# Altium schematic sheet Sheet1.SchDoc
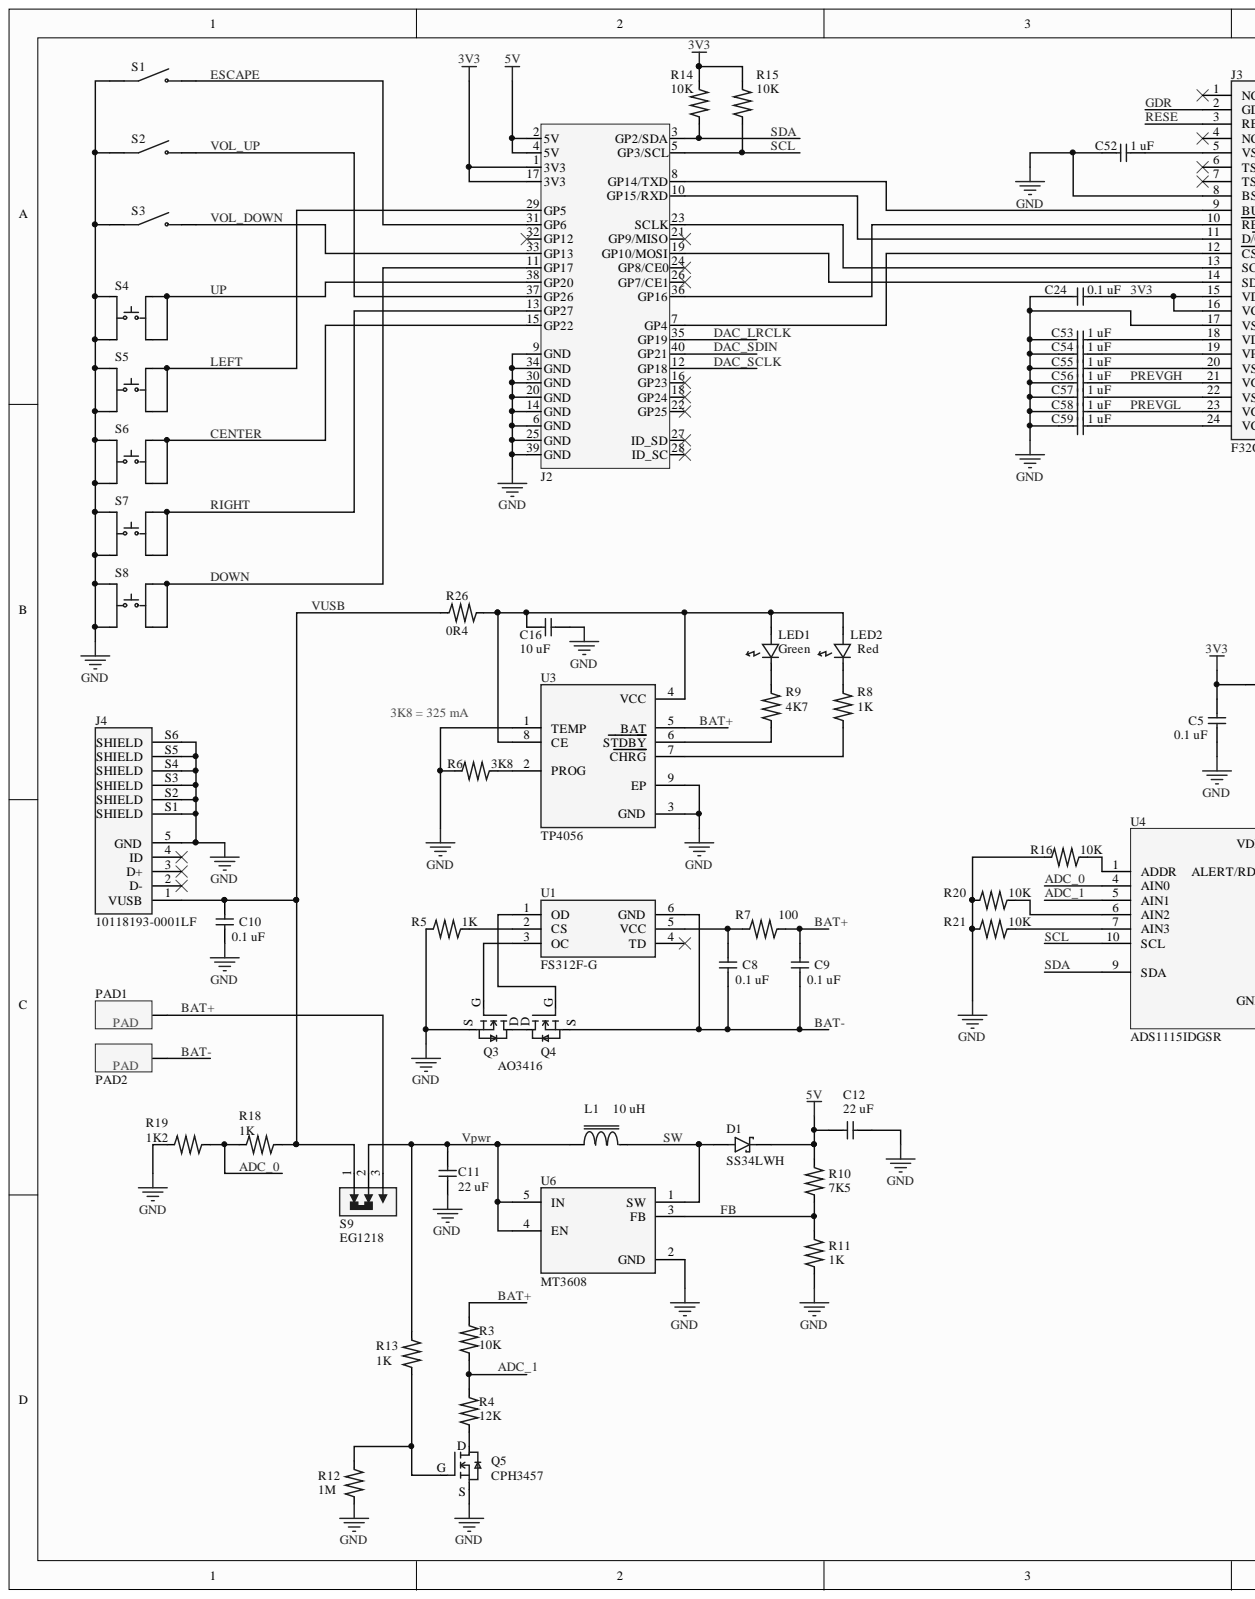
[diagram p0: Sheet1.SchDoc, left]
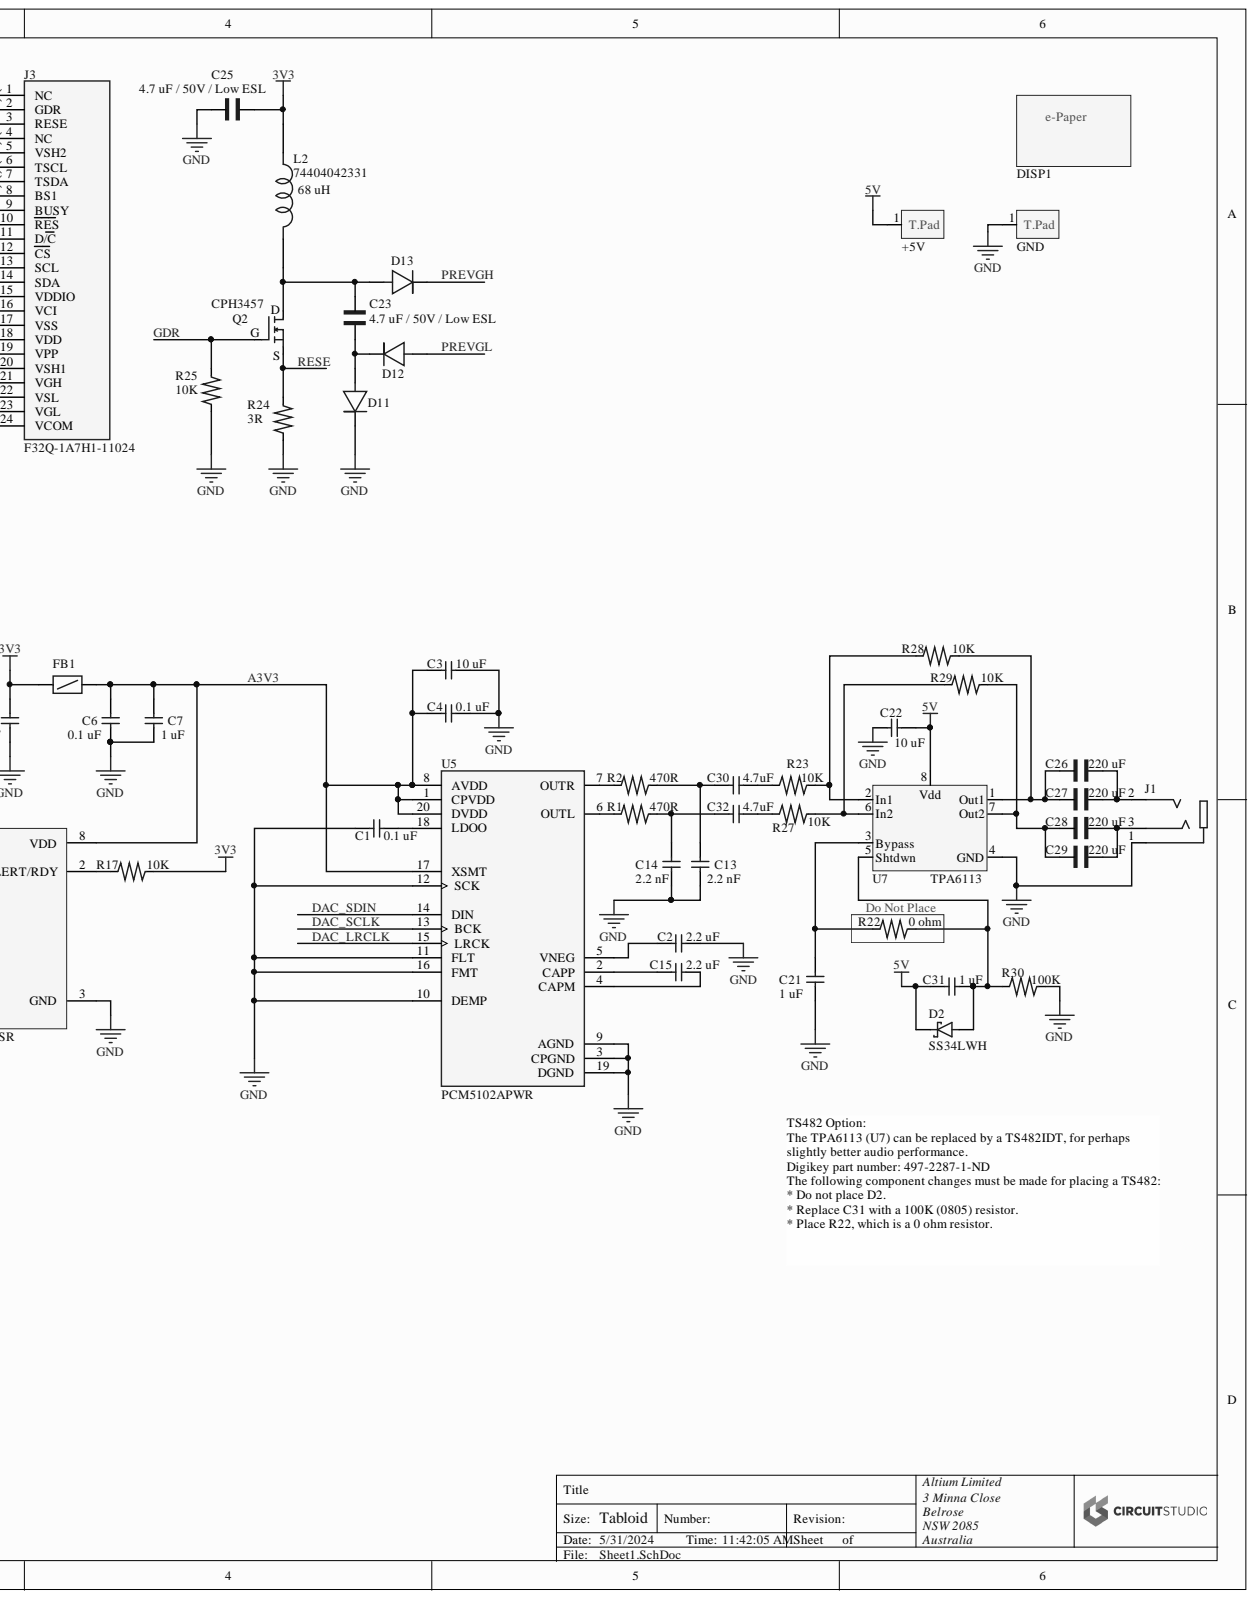
[diagram p1: Sheet1.SchDoc, right]

## Parts
+5V  TestPad  [TestPad]  (value not drawn)
C1  0.1 uF  [Resistor_0805]
C2  2.2 uF  [Resistor_0805]
C3  10 uF  [Resistor_0805]
C4  0.1 uF  [Resistor_0805]
C5  0.1 uF  [Resistor_0805]
C6  0.1 uF  [Resistor_0805]
C7  1 uF  [Resistor_0805]
C8  0.1 uF  [Resistor_0805]
C9  0.1 uF  [Resistor_0805]
C10  0.1 uF  [Resistor_0805]
C11  22 uF  [Resistor_0805]
C12  22 uF  [Resistor_0805]
C13  2.2 nF  [Resistor_0805]
C14  2.2 nF  [Resistor_0805]
C15  2.2 uF  [Resistor_0805]
C16  10 uF  [Resistor_0805]
C21  1 uF  [Resistor_0805]
C22  10 uF  [Resistor_0805]
C23  value 4.7 uF / 50V / Low ESL  [CAPC3216X190N]  (hidden comment: GRM31CR60J227ME11K)
C24  0.1 uF  [Resistor_0805]
C25  value 4.7 uF / 50V / Low ESL  [CAPC3216X190N]  (hidden comment: GRM31CR60J227ME11K)
C26  value 220 uF  [CAPC3216X190N]  (hidden comment: GRM31CR60J227ME11K)
C27  value 220 uF  [CAPC3216X190N]  (hidden comment: GRM31CR60J227ME11K)
C28  value 220 uF  [CAPC3216X190N]  (hidden comment: GRM31CR60J227ME11K)
C29  value 220 uF  [CAPC3216X190N]  (hidden comment: GRM31CR60J227ME11K)
C30  4.7uF  [Resistor_0805]
C31  1 uF  [Resistor_0805]
C32  4.7uF  [Resistor_0805]
C52  1 uF  [Resistor_0805]
C53  1 uF  [Resistor_0805]
C54  1 uF  [Resistor_0805]
C55  1 uF  [Resistor_0805]
C56  1 uF  [Resistor_0805]
C57  1 uF  [Resistor_0805]
C58  1 uF  [Resistor_0805]
C59  1 uF  [Resistor_0805]
D1  SS34LWH  [SODFL3718X102N]
D2  SS34LWH  [SODFL3718X102N]
D11  Diode_SOD-123  [SOD-123]  (value not drawn)
D12  Diode_SOD-123  [SOD-123]  (value not drawn)
D13  Diode_SOD-123  [SOD-123]  (value not drawn)
DISP1  e-Paper_disp  [PCBComponent_1]  (value not drawn)
FB1  Ferrite_0805  [Resistor_0805]  (value not drawn)
GND  TestPad  [TestPad]  (value not drawn)
J1  35RAPC2BHN2  [SWITCHCRAFT_35RAPC2BHN2]  (value not drawn)
J2  Raspi_header  [40P_HDR_SMD]  (value not drawn)
J3  F32Q-1A7H1-11024  [AMPHENOL_F32Q-1A7H1-11024]
J4  10118193-0001LF  [AMPHENOL_10118193-0001LF]
L1  10 uH  [Inductor_2424]
L2  74404042331  value 68 uH  [IND_74404042331]
LED1  Green  [LED_0805]
LED2  Red  [LED_0805]
PAD1  Batt_Pad  [Batt_Pad]  (value not drawn)
PAD2  Batt_Pad  [Batt_Pad]  (value not drawn)
Q2  CPH3457  [SOT-23]
Q3  NFET_SOT23  [SOT-23]  (value not drawn)
Q4  AO3416  [SOT-23]
Q5  CPH3457  [SOT-23]
R1  470R  [Resistor_0805]
R2  470R  [Resistor_0805]
R3  10K  [Resistor_0805]
R4  12K  [Resistor_0805]
R5  1K  [Resistor_0805]
R6  3K8  [Resistor_0805]
R7  100  [Resistor_0805]
R8  1K  [Resistor_0805]
R9  4K7  [Resistor_0805]
R10  7K5  [Resistor_0805]
R11  1K  [Resistor_0805]
R12  1M  [Resistor_0805]
R13  1K  [Resistor_0805]
R14  10K  [Resistor_0805]
R15  10K  [Resistor_0805]
R16  10K  [Resistor_0805]
R17  10K  [Resistor_0805]
R18  1K  [Resistor_0805]
R19  1K2  [Resistor_0805]
R20  10K  [Resistor_0805]
R21  10K  [Resistor_0805]
R22  0 ohm  [Resistor_0805]
R23  10K  [Resistor_0805]
R24  3R  [Resistor_0805]
R25  10K  [Resistor_0805]
R26  0R4  [Resistor_1206]
R27  10K  [Resistor_0805]
R28  10K  [Resistor_0805]
R29  10K  [Resistor_0805]
R30  100K  [Resistor_0805]
S1  TL3330AF130QG  [SW_TL3330AF130QG]  (value not drawn)
S2  TL3330AF130QG  [SW_TL3330AF130QG]  (value not drawn)
S3  TL3330AF130QG  [SW_TL3330AF130QG]  (value not drawn)
S4  PushButton  [PB_switch]  (value not drawn)
S5  PushButton  [PB_switch]  (value not drawn)
S6  PushButton  [PB_switch]  (value not drawn)
S7  PushButton  [PB_switch]  (value not drawn)
S8  PushButton  [PB_switch]  (value not drawn)
S9  EG1218  [SW_EG1218]
U1  FS312F-G  [SOT23-6]
U3  TP4056  [SOP127P600X175-9N]
U4  ADS1115IDGSR  [SOP50P490X110-10N]
U5  PCM5102APWR  [SOP65P640X120-20N]
U6  MT3608  [SOT95P280X145-6N]
U7  TPA6113  [SOIC8]

## Nets
5V: +5V.1 C12.1 C22.2 C31.1 D1.C D2.C J2.2(5V) J2.4(5V) R10.2 U7.8
GND: C1.1 C2.2 C3.1 C4.1 C5.1 C6.1 C7.1 C10.1 C11.1 C12.2 C13.1 C14.1 C16.1 C21.1 C22.1 C24.1 C25.1 C52.1 C53.1 C54.1 C55.1 C56.1 C57.1 C58.1 C59.1 D11.K GND.1 J1.1 J2.6(GND) J2.9(GND) J2.14(GND) J2.20(GND) J2.25(GND) J2.30(GND) J2.34(GND) J2.39(GND) J3.8(BS1) J3.17(VSS) J4.5(GND) J4.S1(SHIELD) J4.S2(SHIELD) J4.S3(SHIELD) J4.S4(SHIELD) J4.S5(SHIELD) J4.S6(SHIELD) Q3.S Q5.S R5.1 R6.1 R11.1 R12.1 R16.1 R19.1 R20.1 R21.1 R24.1 R25.1 R30.2 S1.2 S2.2 S3.2 S4.3 S4.4 S5.3 S5.4 S6.3 S6.4 S7.3 S7.4 S8.3 S8.4 U3.1(TEMP) U3.3(GND) U3.9(EP) U4.3(GND) U5.3(CPGND) U5.9(AGND) U5.10(DEMP) U5.11(FLT) U5.12(SCK) U5.16(FMT) U5.19(DGND) U6.2(GND) U7.4(GND)
3V3: C5.2 C24.2 C25.2 FB1.1 J2.1(3V3) J2.17(3V3) J3.15(VDDIO) J3.16(VCI) L2.1 R14.2 R15.2 R17.2
A3V3: C3.2 C4.2 C6.2 C7.2 FB1.2 U4.8(VDD) U5.1(CPVDD) U5.8(AVDD) U5.17(XSMT) U5.20(DVDD)
ADC_0: R18.1 R19.2 U4.4(AIN0)
ADC_1: R3.1 R4.2 U4.5(AIN1)
BAT+: C9.2 PAD1.1 R3.2 R7.2 S9.3 U3.5(BAT)
BAT-: C8.1 C9.1 PAD2.1 Q4.S U1.6(GND)
CENTER: J2.15(GP22) S6.1 S6.2
DAC_LRCLK: J2.35(GP19) U5.15(LRCK)
DAC_SCLK: J2.12(GP18) U5.13(BCK)
DAC_SDIN: J2.40(GP21) U5.14(DIN)
DOWN: J2.11(GP17) S8.1 S8.2
ESCAPE: J2.31(GP6) S1.1
FB: R10.1 R11.2 U6.3(FB)
GDR: J3.2(GDR) Q2.G R25.2
LEFT: J2.29(GP5) S5.1 S5.2
PREVGH: C56.2 D13.K J3.21(VGH)
PREVGL: C58.2 D12.A J3.23(VGL)
RESE: J3.3(RESE) Q2.S R24.2
RIGHT: J2.13(GP27) S7.1 S7.2
SCL: J2.5(GP3/SCL) R15.1 U4.10(SCL)
SDA: J2.3(GP2/SDA) R14.1 U4.9(SDA)
SW: D1.A L1.2 U6.1(SW)
UP: J2.38(GP20) S4.1 S4.2
VOL_DOWN: J2.33(GP13) S3.1
VOL_UP: J2.37(GP26) S2.1
VPWR: C11.2 L1.1 R13.2 S9.2 U6.4(EN) U6.5(IN)
VUSB: C10.2 J4.1(VUSB) R18.2 R26.2 S9.1
NetC1_2: C1.2 U5.18(LDOO)
NetC2_1: C2.1 U5.5(VNEG)
NetC8_2: C8.2 R7.1 U1.5(VCC)
NetC13_2: C13.2 C30.2 R2.2
NetC14_2: C14.2 C32.2 R1.2
NetC15_1: C15.1 U5.2(CAPP)
NetC15_2: C15.2 U5.4(CAPM)
NetC16_2: C16.2 LED1.A LED2.A R26.1 U3.4(VCC) U3.8(CE)
NetC21_2: C21.2 R22.1 U7.3(Bypass)
NetC23_1: C23.1 D11.A D12.K
NetC23_2: C23.2 D13.A L2.2 Q2.D
NetC26_1: C26.1 C27.1 R28.2 U7.1(Out1)
NetC26_2: C26.2 C27.2 J1.2
NetC28_1: C28.1 C29.1 R29.2 U7.7(Out2)
NetC28_2: C28.2 C29.2 J1.3
NetC30_1: C30.1 R23.1
NetC31_2: C31.2 D2.A R22.2 R30.1 U7.5(Shtdwn)
NetC32_1: C32.1 R27.1
NetC52_2: C52.2 J3.5(VSH2)
NetC53_2: C53.2 J3.18(VDD)
NetC54_2: C54.2 J3.19(VPP)
NetC55_2: C55.2 J3.20(VSH1)
NetC57_2: C57.2 J3.22(VSL)
NetC59_2: C59.2 J3.24(VCOM)
NetJ2_7: J2.7(GP4) J3.12(CS)
NetJ2_8: J2.8(GP14/TXD) J3.9(BUSY)
NetJ2_10: J2.10(GP15/RXD) J3.11(D/C)
NetJ2_19: J2.19(GP10/MOSI) J3.14(SDA)
NetJ2_23: J2.23(SCLK) J3.13(SCL)
NetJ2_36: J2.36(GP16) J3.10(RES)
NetLED1_K: LED1.K R9.2
NetLED2_K: LED2.K R8.2
NetQ3_D: Q3.D Q4.D
NetQ3_G: Q3.G U1.3(OC)
NetQ4_G: Q4.G U1.1(OD)
NetQ5_D: Q5.D R4.1
NetQ5_G: Q5.G R12.2 R13.1
NetR1_1: R1.1 U5.6(OUTL)
NetR2_1: R2.1 U5.7(OUTR)
NetR5_2: R5.2 U1.2(CS)
NetR6_2: R6.2 U3.2(PROG)
NetR8_1: R8.1 U3.7(CHRG)
NetR9_1: R9.1 U3.6(STDBY)
NetR16_2: R16.2 U4.1(ADDR)
NetR17_1: R17.1 U4.2(ALERT/RDY)
NetR20_2: R20.2 U4.6(AIN2)
NetR21_2: R21.2 U4.7(AIN3)
NetR23_2: R23.2 R28.1 U7.2(In1)
NetR27_2: R27.2 R29.1 U7.6(In2)

Not connected: J2 16(GP23) 18(GP24) 21(GP9/MISO) 22(GP25) 24(GP8/CE0) 26(GP7/CE1) 27(ID_SD) 28(ID_SC) 32(GP12); J3 1(NC) 4(NC) 6(TSCL) 7(TSDA); J4 2(D-) 3(D+) 4(ID); U1 4(TD)

## Library data of the parts
+5V GND = TestPad: Test pad; Component Kind: Standard; Library Name: epipod.SchLib
C1-C16 C21 C22 C24 C30-C32 C52-C59 = Capacitor_0805: Component Kind: Standard; Description: Capacitor_0805; Library Name: epipod.IntLib
C23 C25 = GRM31CR60J227ME11K: CAP 220UF 6.3VDC X5R 20% SMD 1206 EMBOSSED TAPE; Value: 4.7 uF / 50V / Low ESL; Availability: Unavailable; Component Kind: Standard; Library Name: GRM31CR60J227ME11K.IntLib; MP: GRM31CR60J227ME11K; Package: None; Price: None
C26-C29 = GRM31CR60J227ME11K: CAP 220UF 6.3VDC X5R 20% SMD 1206 EMBOSSED TAPE; Availability: Unavailable; Component Kind: Standard; Library Name: GRM31CR60J227ME11K.IntLib; MP: GRM31CR60J227ME11K; Package: None; Price: None
D1 D2 = SS34LWH: 3A, 40V, Schottky Rectifier; MF: Taiwan Semiconductor; Availability: In Stock; Check_prices: https://www.snapeda.com/parts/SS34LWH/Taiwan+Semiconductor/view-part/?ref=eda; Component Kind: Standard; Library Name: SS34LWH.IntLib; MP: SS34LWH; Package: SOD-123W Taiwan Semiconductor; Price: None; Purchase-URL: https://pricing.snapeda.com/search/part/SS34LWH/?ref=eda; SnapEDA_Link: https://www.snapeda.com/parts/SS34LWH/Taiwan+Semiconductor/view-part/?ref=snap
D11-D13 = Diode_SOD-123: Diode epaper; Component Kind: Standard; Library Name: epipod.IntLib
DISP1 = e-Paper_disp: e-Paper display; Component Kind: Standard; Library Name: epipod.IntLib
FB1 = Ferrite_0805: Ferrite Bead 0805; Component Kind: Standard; Library Name: epipod.IntLib
J1 = 35RAPC2BHN2: 3.50mm (0.141, 1/8, Mini Plug) - Headphone Phone Jack Stereo (3 Conductor, TRS) Connector Solder; MF: Switchcraft Inc.; Availability: Good; Check_prices: https://www.snapeda.com/parts/35RAPC2BHN2/Switchcraft%20Inc./view-part/380634/?ref=eda; Component Kind: Standard; Library Name: 35RAPC2BHN2.IntLib; MP: 35RAPC2BHN2; Package: None; Price: None; Purchase-URL: https://pricing.snapeda.com/search/part/35RAPC2BHN2/?ref=eda; SnapEDA_Link: https://www.snapeda.com/parts/35RAPC2BHN2/Switchcraft%20Inc./view-part/380634/?ref=snap
J2 = Raspi_header: Raspi Header; Component Kind: Standard; Library Name: epipod.IntLib
J3 = F32Q-1A7H1-11024: 24 Position FFC, FPC Connector Contacts, Top 0.020 (0.50mm) Surface Mount, Right Angle; MF: Amphenol; Availability: In Stock; Component Kind: Standard; Library Name: F32Q-1A7H1-11024.IntLib; MP: F32Q-1A7H1-11024; Package: None; Price: None; Purchase-URL: https://pricing.snapeda.com/search?q=F32Q-1A7H1-11024&ref=eda
J4 = 10118193-0001LF: Micro USB 2.0, Input Output Connectors, B TYPE RECEPTACLE; MF: Amphenol ICC; Availability: In Stock; Check_prices: https://www.snapeda.com/parts/10118193-0001LF/Amphenol+FCI/view-part/?ref=eda; Component Kind: Standard; Library Name: 10118193-0001LF.IntLib; MP: 10118193-0001LF; Package: None; Price: None; Purchase-URL: https://pricing.snapeda.com/search/part/10118193-0001LF/?ref=eda; SnapEDA_Link: https://www.snapeda.com/parts/10118193-0001LF/Amphenol+FCI/view-part/?ref=snap
L1 = Inductor_1: Boost Inductor; Component Kind: Standard; Library Name: epipod.IntLib
L2 = 74404042331: Inductors; Fixed; WE-LQS SMD 4018 330uH 0.2A 530mOhms; Availability: Unavailable; Component Kind: Standard; Library Name: 74404042331.IntLib; MP: 74404042331; Package: None; Price: None
LED1 LED2 = LED_0805: LED; Component Kind: Standard; Library Name: epipod.IntLib
PAD1 PAD2 = Batt_Pad: Battery Pad; Component Kind: Standard; Library Name: epipod.IntLib
Q2-Q5 = NFET_SOT23: N-ch FET; Component Kind: Standard; Library Name: epipod.IntLib
R1-R25 R27-R30 = Resistor_0805: Component Kind: Standard; Description: Resistor_0805; Library Name: epipod.IntLib
R26 = Resistor_1206: Resistor 1206; Component Kind: Standard; Library Name: epipod.IntLib
S1-S3 = TL3330AF130QG: Tactile Switch SPST-NO Side Actuated Surface Mount, Right Angle; MF: E-Switch; Availability: In Stock; Check_prices: https://www.snapeda.com/parts/TL3330AF130QG/E-Switch/view-part/?ref=eda; Component Kind: Standard; Library Name: TL3330AF130QG.IntLib; MP: TL3330AF130QG; Package: None; Price: None; Purchase-URL: https://pricing.snapeda.com/search/part/TL3330AF130QG/?ref=eda; SnapEDA_Link: https://www.snapeda.com/parts/TL3330AF130QG/E-Switch/view-part/?ref=snap
S4-S8 = PushButton: Push Button; Component Kind: Standard; Library Name: epipod.IntLib
S9 = EG1218: Slide Switch, EG Series, SPDT, Non-Shorting, ON-ON, 200mA DC, 30VDC, PC Pin | E-Switch EG1218; Check_prices: https://www.snapeda.com/parts/EG1218/E-Switch/view-part/?ref=eda; Component Kind: Standard; Library Name: EG1218.IntLib; MF: E-Switch; MP: EG1218; Package: None; SnapEDA_Link: https://www.snapeda.com/parts/EG1218/E-Switch/view-part/?ref=snap
U1 = FS312F-G: Battery Protection IC LCSC C82736; MF: Fortune Semiconductor; Availability: Not in stock; Check_prices: https://www.snapeda.com/parts/FS312F-G/Fortune+Semiconductor/view-part/?ref=eda; Component Kind: Standard; Library Name: FS312F-G.IntLib; MP: FS312F-G; Package: Package; Price: None; SnapEDA_Link: https://www.snapeda.com/parts/FS312F-G/Fortune+Semiconductor/view-part/?ref=snap
U3 = TP4056: Complete single cell Li-Ion battery with a constant current / constant voltage linear charger; MF: NanJing Top Power ASIC Corp.; Availability: Not in stock; Check_prices: https://www.snapeda.com/parts/TP4056/NanJing+Top+Power+ASIC+Corp./view-part/?ref=eda; Component Kind: Standard; Library Name: TP4056.IntLib; MP: TP4056; Package: Package; Price: None; SnapEDA_Link: https://www.snapeda.com/parts/TP4056/NanJing+Top+Power+ASIC+Corp./view-part/?ref=snap
U4 = ADS1115IDGSR: MP: altium_native_batch; Availability: Unavailable; Component Kind: Standard; Library Name: ADS1115IDGSR.IntLib; Package: None; Price: None
U5 = PCM5102APWR: 2VRMS DirectPath, 112dB Audio Stereo DAC with 32-bit, 384kHz PCM Interface 20-TSSOP -25 to 85; Availability: Unavailable; Component Kind: Standard; Library Name: PCM5102APWR.IntLib; MP: PCM5102APWR; Package: None; Price: None
U6 = MT3608: High Efficiency 1.2MHz2A Step Up Converter; MF: Aerosemi Technology Co., Ltd; Availability: Not in stock; Check_prices: https://www.snapeda.com/parts/MT3608/Aerosemi+Technology+Co.%252C+Ltd/view-part/?ref=eda; Component Kind: Standard; Library Name: MT3608.IntLib; MP: MT3608; Package: Package; Price: None; SnapEDA_Link: https://www.snapeda.com/parts/MT3608/Aerosemi+Technology+Co.%252C+Ltd/view-part/?ref=snap
U7 = TPA6113_amp: Headphone Amp; Component Kind: Standard; Library Name: epipod.IntLib
